# Altium schematic sheet PowerAndMisc.SchDoc(PowerAndMisc)
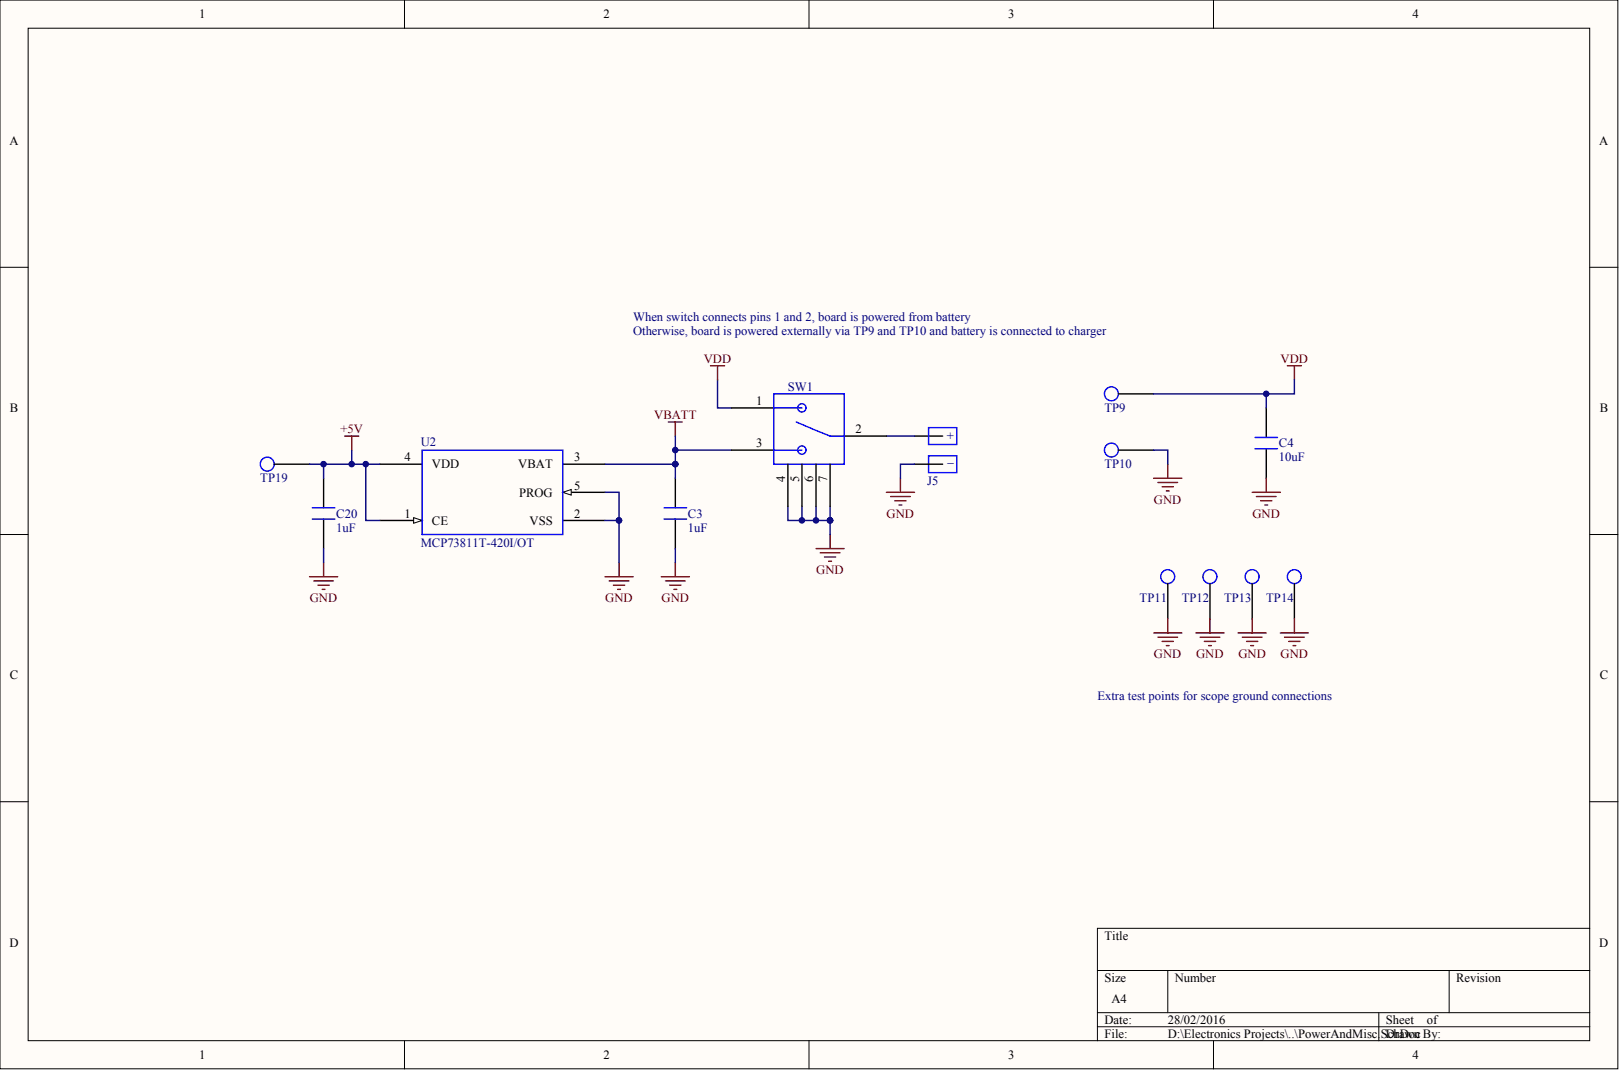
[diagram p0: PowerAndMisc.SchDoc]

## Parts
C3  Capacitance: 1uF  (hidden comment: CAP_0402_1uF)
C4  Capacitance: 10uF  (hidden comment: CAP_0603_10uF)
C20  Capacitance: 1uF  (hidden comment: CAP_0402_1uF)
J5  CONN_LI-POLY_PADS  (value not drawn)
SW1  SW_CUS-12TB  (value not drawn)
TP9  TP_5018  (value not drawn)
TP10  TP_5018  (value not drawn)
TP11  TP_5018  (value not drawn)
TP12  TP_5018  (value not drawn)
TP13  TP_5018  (value not drawn)
TP14  TP_5018  (value not drawn)
TP19  TP_5018  (value not drawn)
U2  MCP73811  Part Number: MCP73811T-420I/OT

## Nets
+5V: C20.2 TP19.1 U2.1(CE) U2.4(VDD)
GND: C3.1 C4.1 C20.1 J5.2 SW1.4 SW1.5 SW1.6 SW1.7 TP10.1 TP11.1 TP12.1 TP13.1 TP14.1 U2.2(VSS) U2.5(PROG)
VBATT: C3.2 SW1.3 U2.3(VBAT)
VDD: C4.2 SW1.1 TP9.1
NetJ5_1: J5.1 SW1.2

## Library data of the parts
C3 C20 = (no symbol name): Manufacturer: TDK; Part Number: C1005X5R1A105K050BB; Tolerance: 10%; Voltage: 10V
C4 = (no symbol name): Manufacturer: Samsung; Part Number: CL10A106MQ8NNNC; Tolerance: 20%; Voltage: 6.3V
SW1 = (no symbol name): Manufacturer: Copal Electronics; Part Number: CUS-12TB
TP9-TP14 TP19 = (no symbol name): Manufacturer: Keystone Electronics; Part Number: 5018
U2 = (no symbol name): Manufacturer: Microchip; Package: SOT-23-5
